# Altium schematic sheet GameBoy Connections.SchDoc("GameBoy Connections")
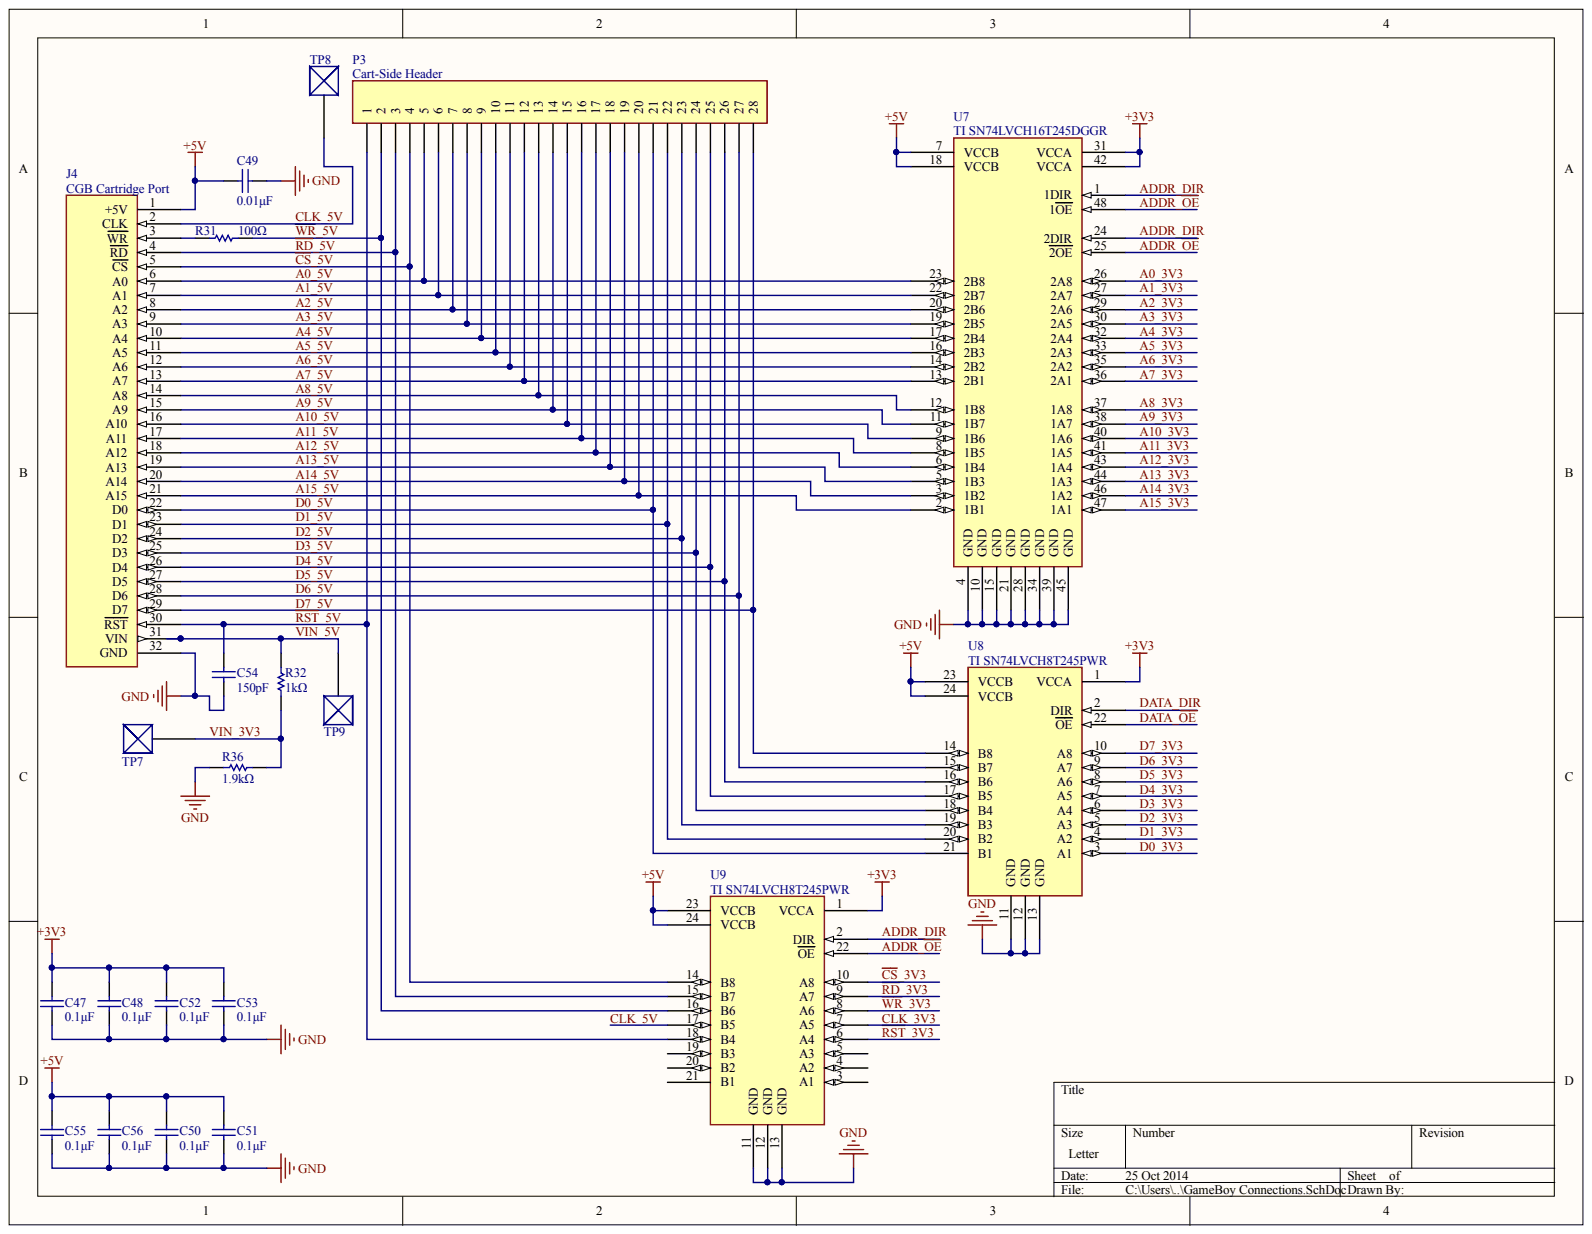
[diagram p0: GameBoy Connections.SchDoc]

## Parts
C47  value 0.1μF  [C0805]  (hidden comment: Cap)
C48  value 0.1μF  [C0805]  (hidden comment: Cap)
C49  value 0.01μF  [C0805]  (hidden comment: Cap)
C50  value 0.1μF  [C0805]  (hidden comment: Cap)
C51  value 0.1μF  [C0805]  (hidden comment: Cap)
C52  value 0.1μF  [C0805]  (hidden comment: Cap)
C53  value 0.1μF  [C0805]  (hidden comment: Cap)
C54  value 150pF  [C0805]  (hidden comment: Cap)
C55  value 0.1μF  [C0805]  (hidden comment: Cap)
C56  value 0.1μF  [C0805]  (hidden comment: Cap)
J4  CGB Cartridge Port  [1X32_GB]
P3  Cart-Side Header  [HDR1X28]
R31  value 100Ω  [C0805]  (hidden comment: Res1)
R32  value 1kΩ  [C0805]  (hidden comment: Res1)
R36  value 1.9kΩ  [C0805]  (hidden comment: Res1)
TP7  Testpoint  [TESTPOINT]  (value not drawn)
TP8  Testpoint  [TESTPOINT]  (value not drawn)
TP9  Testpoint  [TESTPOINT]  (value not drawn)
U7  TI SN74LVCH16T245DGGR  [TI TSSOP-48 (DGG)]
U8  TI SN74LVCH8T245PWR  [TI TSSOP-24 (DGG)]
U9  TI SN74LVCH8T245PWR  [TI TSSOP-24 (DGG)]

## Nets
+3V3: C47.1 C48.1 C52.1 C53.1 U7.31(VCCA) U7.42(VCCA) U8.1(VCCA) U9.1(VCCA)
+5V: C49.2 C50.1 C51.1 C55.1 C56.1 J4.1(+5V) U7.7(VCCB) U7.18(VCCB) U8.23(VCCB) U8.24(VCCB) U9.23(VCCB) U9.24(VCCB)
GND: C47.2 C48.2 C49.1 C50.2 C51.2 C52.2 C53.2 C54.2 C55.2 C56.2 J4.32(GND) R36.1 U7.4(GND) U7.10(GND) U7.15(GND) U7.21(GND) U7.28(GND) U7.34(GND) U7.39(GND) U7.45(GND) U8.11(GND) U8.12(GND) U8.13(GND) U9.11(GND) U9.12(GND) U9.13(GND)
A0_3V3: U7.26(2A8)
A0_5V: J4.6(A0) P3.5 U7.23(2B8)
A1_3V3: U7.27(2A7)
A1_5V: J4.7(A1) P3.6 U7.22(2B7)
A2_3V3: U7.29(2A6)
A2_5V: J4.8(A2) P3.7 U7.20(2B6)
A3_3V3: U7.30(2A5)
A3_5V: J4.9(A3) P3.8 U7.19(2B5)
A4_3V3: U7.32(2A4)
A4_5V: J4.10(A4) P3.9 U7.17(2B4)
A5_3V3: U7.33(2A3)
A5_5V: J4.11(A5) P3.10 U7.16(2B3)
A6_3V3: U7.35(2A2)
A6_5V: J4.12(A6) P3.11 U7.14(2B2)
A7_3V3: U7.36(2A1)
A7_5V: J4.13(A7) P3.12 U7.13(2B1)
A8_3V3: U7.37(1A8)
A8_5V: J4.14(A8) P3.13 U7.12(1B8)
A9_3V3: U7.38(1A7)
A9_5V: J4.15(A9) P3.14 U7.11(1B7)
A10_3V3: U7.40(1A6)
A10_5V: J4.16(A10) P3.15 U7.9(1B6)
A11_3V3: U7.41(1A5)
A11_5V: J4.17(A11) P3.16 U7.8(1B5)
A12_3V3: U7.43(1A4)
A12_5V: J4.18(A12) P3.17 U7.6(1B4)
A13_3V3: U7.44(1A3)
A13_5V: J4.19(A13) P3.18 U7.5(1B3)
A14_3V3: U7.46(1A2)
A14_5V: J4.20(A14) P3.19 U7.3(1B2)
A15_3V3: U7.47(1A1)
A15_5V: J4.21(A15) P3.20 U7.2(1B1)
ADDR_DIR: U7.1(1DIR) U7.24(2DIR) U9.2(DIR)
ADDR_~{OE}: U7.25(2OE) U7.48(1OE) U9.22(OE)
~{CS}_3V3: U9.10(A8)
~{CS}_5V: J4.5(CS) P3.4 U9.14(B8)
CLK_3V3: U9.7(A5)
CLK_5V: J4.2(CLK) TP8.1 U9.17(B5)
D0_3V3: U8.3(A1)
D0_5V: J4.22(D0) P3.21 U8.21(B1)
D1_3V3: U8.4(A2)
D1_5V: J4.23(D1) P3.22 U8.20(B2)
D2_3V3: U8.5(A3)
D2_5V: J4.24(D2) P3.23 U8.19(B3)
D3_3V3: U8.6(A4)
D3_5V: J4.25(D3) P3.24 U8.18(B4)
D4_3V3: U8.7(A5)
D4_5V: J4.26(D4) P3.25 U8.17(B5)
D5_3V3: U8.8(A6)
D5_5V: J4.27(D5) P3.26 U8.16(B6)
D6_3V3: U8.9(A7)
D6_5V: J4.28(D6) P3.27 U8.15(B7)
D7_3V3: U8.10(A8)
D7_5V: J4.29(D7) P3.28 U8.14(B8)
DATA_DIR: U8.2(DIR)
DATA_~{OE}: U8.22(OE)
~{RD}_3V3: U9.9(A7)
~{RD}_5V: J4.4(RD) P3.3 U9.15(B7)
~{RST}_3V3: U9.6(A4)
~{RST}_5V: C54.1 J4.30(RST) P3.1 U9.18(B4)
VIN_3V3: R32.2 R36.2 TP7.1
VIN_5V: J4.31(VIN) R32.1 TP9.1
~{WR}_3V3: U9.8(A6)
~{WR}_5V: P3.2 R31.1 U9.16(B6)
NetJ4_3: J4.3(WR) R31.2

Not connected: U9 3(A1) 4(A2) 5(A3) 19(B3) 20(B2) 21(B1)

## Library data of the parts
C47-C56 = Cap: Capacitor; Component Kind: Standard; LatestRevisionDate: 17-Jul-2002; LatestRevisionNote: Re-released for DXP Platform.; Library Name: Miscellaneous Devices.IntLib; PackageDescription: Radial Cap, Thru-Hole; 2 Leads; 0.3 in Pin Spacing; PackageReference: RAD-0.3; Published: 8-Jun-2000; Signal Integrity: Cap; Simulation: CAP; Publisher: Altium Limited
J4 = CGB Cartridge Port: Nintendo GameBoy Color Cartridge Port; Component Kind: Standard; Library Name: TS-Schematic.SchLib
P3 = Header 28: Header, 28-Pin; Component Kind: Standard; LatestRevisionDate: 17-Jul-2002; LatestRevisionNote: Re-released for DXP Platform.; Library Name: TS-Schematic.SchLib; Signal Integrity: Connector; Publisher: Altium Limited
R31 R32 R36 = Res1: Resistor; Component Kind: Standard; LatestRevisionDate: 17-Jul-2002; LatestRevisionNote: Re-released for DXP Platform.; Library Name: Miscellaneous Devices.IntLib; PackageDescription: Axial Device, Thru-Hole; 2 Leads; 0.3 in Pin Spacing; PackageReference: AXIAL-0.3; Published: 8-Jun-2000; Signal Integrity: Res; Simulation: RESISTOR; Publisher: Altium Limited
U7 = TI SN74LVCH16T245DGVR: IC BUS TRANSCVR 16BIT 48TVSOP; Component Kind: Standard; Library Name: TS-Schematic.SchLib
U8 U9 = TI SN74LVCH8T245DGVR: IC BUS TRANSCVR 8BIT 24TVSOP; Component Kind: Standard; Library Name: TS-Schematic.SchLib
TP7-TP9 = Testpoint: Component Kind: Standard; Library Name: TS-Schematic.SchLib
